# Altium schematic sheet Mosfet_and_Driver.SchDoc(Mosfet_and_Driver)
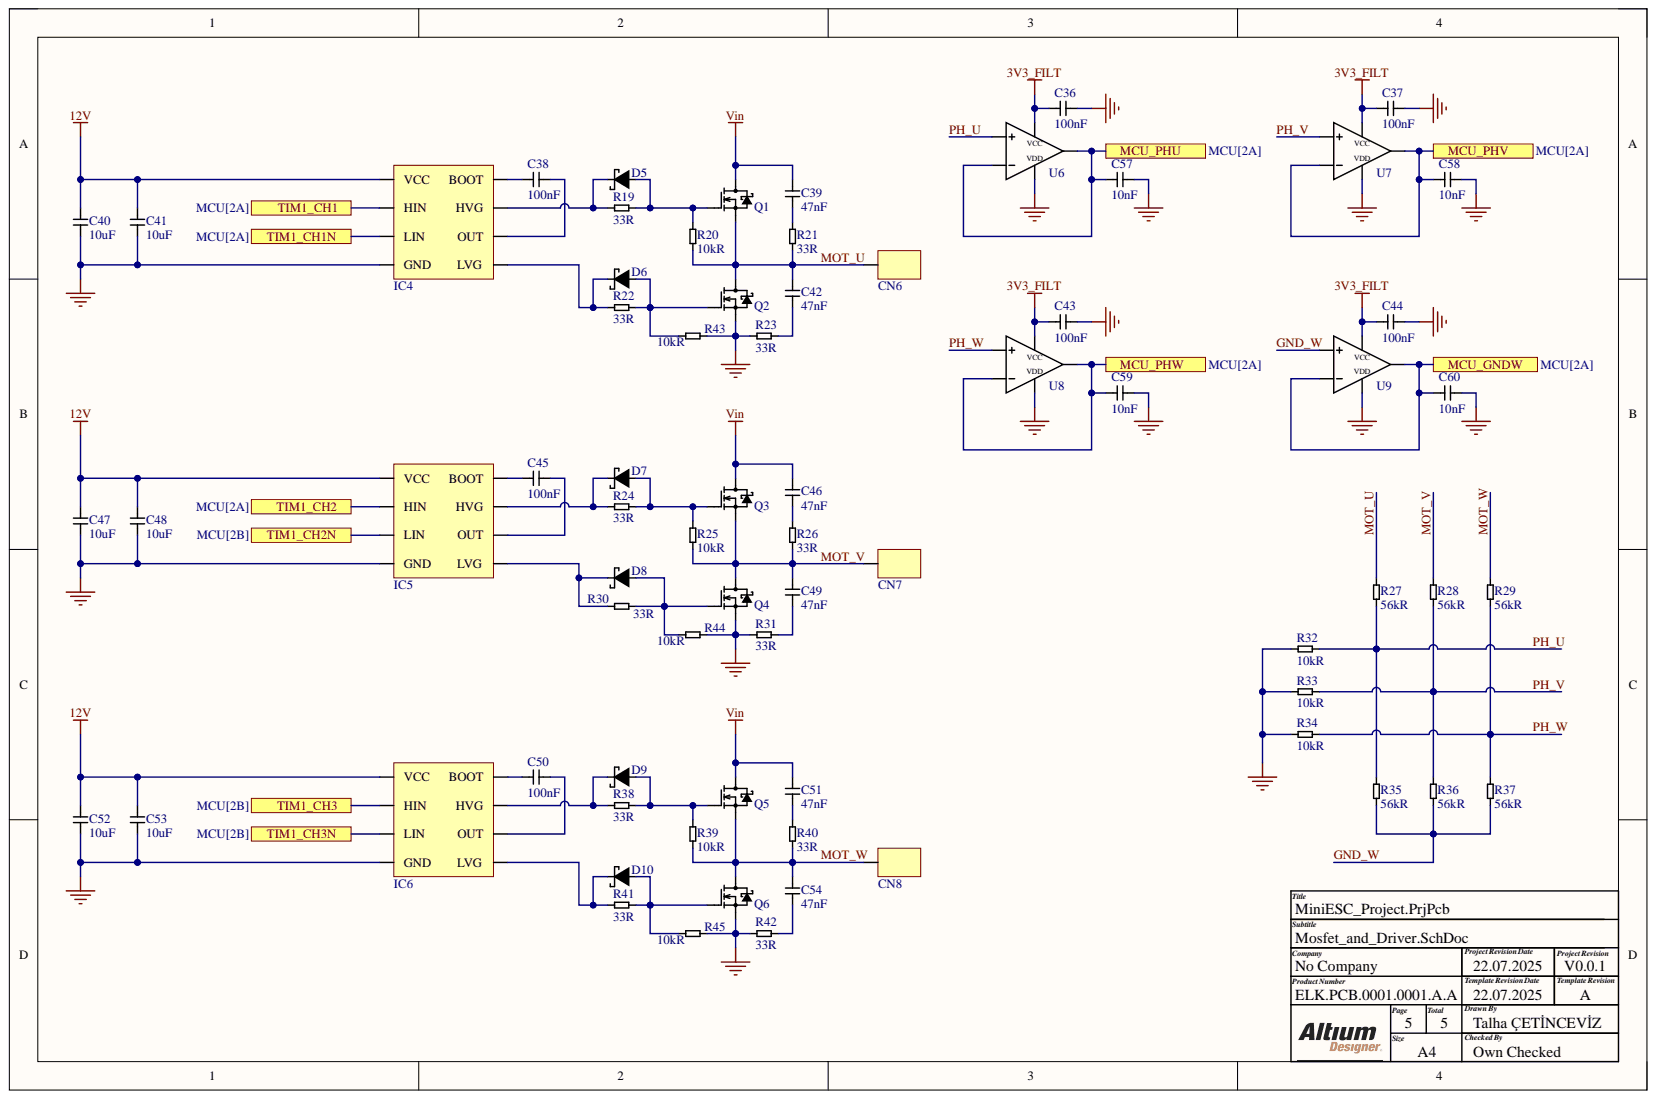
[diagram p0: Mosfet_and_Driver.SchDoc]

## Parts
C36  value 100nF  [CAP 0603]  (hidden comment: CC0603KRX7R9BB104)
C37  value 100nF  [CAP 0603]  (hidden comment: CC0603KRX7R9BB104)
C38  value 100nF  [CAP 0805]  (hidden comment: CL21B104KBCNNNC)
C39  value 47nF  [CAP 1206]  (hidden comment: CL31B473KBCNNNC)
C40  value 10uF  [CAP 0805]  (hidden comment: CL21A106KAYNNNE)
C41  value 10uF  [CAP 0805]  (hidden comment: CL21A106KAYNNNE)
C42  value 47nF  [CAP 1206]  (hidden comment: CL31B473KBCNNNC)
C43  value 100nF  [CAP 0603]  (hidden comment: CC0603KRX7R9BB104)
C44  value 100nF  [CAP 0603]  (hidden comment: CC0603KRX7R9BB104)
C45  value 100nF  [CAP 0805]  (hidden comment: CL21B104KBCNNNC)
C46  value 47nF  [CAP 1206]  (hidden comment: CL31B473KBCNNNC)
C47  value 10uF  [CAP 0805]  (hidden comment: CL21A106KAYNNNE)
C48  value 10uF  [CAP 0805]  (hidden comment: CL21A106KAYNNNE)
C49  value 47nF  [CAP 1206]  (hidden comment: CL31B473KBCNNNC)
C50  value 100nF  [CAP 0805]  (hidden comment: CL21B104KBCNNNC)
C51  value 47nF  [CAP 1206]  (hidden comment: CL31B473KBCNNNC)
C52  value 10uF  [CAP 0805]  (hidden comment: CL21A106KAYNNNE)
C53  value 10uF  [CAP 0805]  (hidden comment: CL21A106KAYNNNE)
C54  value 47nF  [CAP 1206]  (hidden comment: CL31B473KBCNNNC)
C57  value 10nF  [CAP 0603]  (hidden comment: CL10B103KB8NNNC)
C58  value 10nF  [CAP 0603]  (hidden comment: CL10B103KB8NNNC)
C59  value 10nF  [CAP 0603]  (hidden comment: CL10B103KB8NNNC)
C60  value 10nF  [CAP 0603]  (hidden comment: CL10B103KB8NNNC)
CN6  SMD PAD 1  [SMD PAD 1]  (value not drawn)  (no BOM)
CN7  SMD PAD 1  [SMD PAD 1]  (value not drawn)  (no BOM)
CN8  SMD PAD 1  [SMD PAD 1]  (value not drawn)  (no BOM)
D5  SS2060FL_R1_00001  [SOD123-FL]  (value not drawn)
D6  SS2060FL_R1_00001  [SOD123-FL]  (value not drawn)
D7  SS2060FL_R1_00001  [SOD123-FL]  (value not drawn)
D8  SS2060FL_R1_00001  [SOD123-FL]  (value not drawn)
D9  SS2060FL_R1_00001  [SOD123-FL]  (value not drawn)
D10  SS2060FL_R1_00001  [SOD123-FL]  (value not drawn)
IC4  L6388ED013TR  [SOIC-8]  (value not drawn)
IC5  L6388ED013TR  [SOIC-8]  (value not drawn)
IC6  L6388ED013TR  [SOIC-8]  (value not drawn)
Q1  P80FH5ENK-7071  [D2PAK-3]  (value not drawn)
Q2  P80FH5ENK-7071  [D2PAK-3]  (value not drawn)
Q3  P80FH5ENK-7071  [D2PAK-3]  (value not drawn)
Q4  P80FH5ENK-7071  [D2PAK-3]  (value not drawn)
Q5  P80FH5ENK-7071  [D2PAK-3]  (value not drawn)
Q6  P80FH5ENK-7071  [D2PAK-3]  (value not drawn)
R19  value 33R  [RES 0805]  (hidden comment: 0805S8F330JT5E)
R20  value 10kR  [RES 0603]  (hidden comment: RC0603FR-0710KL)
R21  value 33R  [RES 1206]  (hidden comment: CQ06S4F330JT5E)
R22  value 33R  [RES 0805]  (hidden comment: 0805S8F330JT5E)
R23  value 33R  [RES 1206]  (hidden comment: CQ06S4F330JT5E)
R24  value 33R  [RES 0805]  (hidden comment: 0805S8F330JT5E)
R25  value 10kR  [RES 0603]  (hidden comment: RC0603FR-0710KL)
R26  value 33R  [RES 1206]  (hidden comment: CQ06S4F330JT5E)
R27  value 56kR  [RES 0603]  (hidden comment: 0603SAF5602T5E)
R28  value 56kR  [RES 0603]  (hidden comment: 0603SAF5602T5E)
R29  value 56kR  [RES 0603]  (hidden comment: 0603SAF5602T5E)
R30  value 33R  [RES 0805]  (hidden comment: 0805S8F330JT5E)
R31  value 33R  [RES 1206]  (hidden comment: CQ06S4F330JT5E)
R32  value 10kR  [RES 0603]  (hidden comment: RC0603FR-0710KL)
R33  value 10kR  [RES 0603]  (hidden comment: RC0603FR-0710KL)
R34  value 10kR  [RES 0603]  (hidden comment: RC0603FR-0710KL)
R35  value 56kR  [RES 0603]  (hidden comment: 0603SAF5602T5E)
R36  value 56kR  [RES 0603]  (hidden comment: 0603SAF5602T5E)
R37  value 56kR  [RES 0603]  (hidden comment: 0603SAF5602T5E)
R38  value 33R  [RES 0805]  (hidden comment: 0805S8F330JT5E)
R39  value 10kR  [RES 0603]  (hidden comment: RC0603FR-0710KL)
R40  value 33R  [RES 1206]  (hidden comment: CQ06S4F330JT5E)
R41  value 33R  [RES 0805]  (hidden comment: 0805S8F330JT5E)
R42  value 33R  [RES 1206]  (hidden comment: CQ06S4F330JT5E)
R43  value 10kR  [RES 0603]  (hidden comment: RC0603FR-0710KL)
R44  value 10kR  [RES 0603]  (hidden comment: RC0603FR-0710KL)
R45  value 10kR  [RES 0603]  (hidden comment: RC0603FR-0710KL)
U6  LMV321ILT  [SOT23-5]  (value not drawn)
U7  LMV321ILT  [SOT23-5]  (value not drawn)
U8  LMV321ILT  [SOT23-5]  (value not drawn)
U9  LMV321ILT  [SOT23-5]  (value not drawn)

## Ports
MCU_GNDW, MCU_PHU, MCU_PHV, MCU_PHW, TIM1_CH1, TIM1_CH1N, TIM1_CH2, TIM1_CH2N, TIM1_CH3, TIM1_CH3N

## Nets
3V3_FILT: C36.1 C37.1 C43.1 C44.1 U6.5 U7.5 U8.5 U9.5
12V: C40.2 C41.2 C47.2 C48.2 C52.2 C53.2 IC4.3(VCC) IC5.3(VCC) IC6.3(VCC)
GND: C36.2 C37.2 C40.1 C41.1 C43.2 C44.2 C47.1 C48.1 C52.1 C53.1 C57.2 C58.2 C59.2 C60.2 IC4.4(GND) IC5.4(GND) IC6.4(GND) Q2.3 Q4.3 Q6.3 R23.2 R31.2 R32.2 R33.2 R34.2 R42.2 R43.2 R44.2 R45.2 U6.2 U7.2 U8.2 U9.2
Vin: C39.2 C46.2 C51.2 Q1.2 Q3.2 Q5.2
GND_W: R35.1 R36.1 R37.1 U9.1
MCU_GNDW: C60.1 U9.3 U9.4 ; port MCU_GNDW
MCU_PHU: C57.1 U6.3 U6.4 ; port MCU_PHU
MCU_PHV: C58.1 U7.3 U7.4 ; port MCU_PHV
MCU_PHW: C59.1 U8.3 U8.4 ; port MCU_PHW
MOT_U: C42.2 CN6.1 Q1.3 Q2.2 R20.1 R21.1 R27.2
MOT_V: C49.2 CN7.1 Q3.3 Q4.2 R25.1 R26.1 R28.2
MOT_W: C54.2 CN8.1 Q5.3 Q6.2 R29.2 R39.1 R40.1
PH_U: R27.1 R32.1 R35.2 U6.1
PH_V: R28.1 R33.1 R36.2 U7.1
PH_W: R29.1 R34.1 R37.2 U8.1
TIM1_CH1: IC4.2(HIN) ; port TIM1_CH1
TIM1_CH1N: IC4.1(LIN) ; port TIM1_CH1N
TIM1_CH2: IC5.2(HIN) ; port TIM1_CH2
TIM1_CH2N: IC5.1(LIN) ; port TIM1_CH2N
TIM1_CH3: IC6.2(HIN) ; port TIM1_CH3
TIM1_CH3N: IC6.1(LIN) ; port TIM1_CH3N
NetC38_1: C38.1 IC4.8(BOOT)
NetC38_2: C38.2 IC4.6(OUT)
NetC39_1: C39.1 R21.2
NetC42_1: C42.1 R23.1
NetC45_1: C45.1 IC5.8(BOOT)
NetC45_2: C45.2 IC5.6(OUT)
NetC46_1: C46.1 R26.2
NetC49_1: C49.1 R31.1
NetC50_1: C50.1 IC6.8(BOOT)
NetC50_2: C50.2 IC6.6(OUT)
NetC51_1: C51.1 R40.2
NetC54_1: C54.1 R42.1
NetD5_A: D5.A Q1.1 R19.2 R20.2
NetD5_C: D5.C IC4.7(HVG) R19.1
NetD6_A: D6.A Q2.1 R22.2 R43.1
NetD6_C: D6.C IC4.5(LVG) R22.1
NetD7_A: D7.A Q3.1 R24.2 R25.2
NetD7_C: D7.C IC5.7(HVG) R24.1
NetD8_A: D8.A Q4.1 R30.2 R44.1
NetD8_C: D8.C IC5.5(LVG) R30.1
NetD9_A: D9.A Q5.1 R38.2 R39.2
NetD9_C: D9.C IC6.7(HVG) R38.1
NetD10_A: D10.A Q6.1 R41.2 R45.1
NetD10_C: D10.C IC6.5(LVG) R41.1

## Library data of the parts
C36 C37 C43 C44 = CC0603KRX7R9BB104: Multilayer Ceramic Capacitor, CC Series, 0.1 - F, - 10%, X7R, 50 V, 0603 [1608 Metric]; Manufacturer: Yageo; Dielectric Material: 4241ConductorMaterial; Voltage: 50V; Case Code (Metric): 1608; Case Code (Imperial): 0603; Case/Package: 0603; Category: Ceramic Capacitors; Component Kind: Standard; ComponentLink1Description: https://datasheet.ciiva.com/11786/0900766b80d84f1d-11786061.pdf?src-supplier=Rs; ComponentLink1URL: https://datasheet.ciiva.com/11786/0900766b80d84f1d-11786061.pdf?src-supplier=Rs; ComponentLink2Description: https://datasheet.ciiva.com/8033/70765-8033821.pdf?src-supplier=Element14; ComponentLink2URL: https://datasheet.ciiva.com/8033/70765-8033821.pdf?src-supplier=Element14; ComponentLink3Description: https://datasheet.ciiva.com/7978/1489800-7978166.pdf?src-supplier=Element14; ComponentLink3URL: https://datasheet.ciiva.com/7978/1489800-7978166.pdf?src-supplier=Element14; ComponentLink4Description: https://datasheet.ciiva.com/144/mlccorderingcode-144177.pdf?src-supplier=Digikey; ComponentLink4URL: https://datasheet.ciiva.com/144/mlccorderingcode-144177.pdf?src-supplier=Digikey; ComponentLink5Description: https://datasheet.ciiva.com/144/mlccorderingcode-144176.pdf?src-supplier=Digikey; ComponentLink5URL: https://datasheet.ciiva.com/144/mlccorderingcode-144176.pdf?src-supplier=Digikey; ComponentLink6Description: https://datasheet.ciiva.com/144/mlccorderingcode-144178.pdf?src-supplier=Digikey; ComponentLink6URL: https://datasheet.ciiva.com/144/mlccorderingcode-144178.pdf?src-supplier=Digikey; ComponentLink7Description: https://datasheet.ciiva.com/8033/70765-8033819.pdf?src-supplier=Element14; ComponentLink7URL: https://datasheet.ciiva.com/8033/70765-8033819.pdf?src-supplier=Element14; ComponentLink8Description: https://datasheet.ciiva.com/31394/1489800-31394927.pdf?src-supplier=Farnell; ComponentLink8URL: https://datasheet.ciiva.com/31394/1489800-31394927.pdf?src-supplier=Farnell; ComponentLink9Description: https://datasheet.ciiva.com/22749/1489800-22749214.pdf?src-supplier=Element14; ComponentLink9URL: https://datasheet.ciiva.com/22749/1489800-22749214.pdf?src-supplier=Element14; Depth: 0.8mm; Dielectric: X7R; Halogen Free: 5694HalogenFree; Height: 0.8mm; Lead Free: 5697LeadFree; Length: 0.063inch; Library Name: ESC_Int.IntLib; Manufacturer Part Number: CC0603KRX7R9BB104; Material: 4241ConductorMaterial; Max Operating Temperature: 125°C; Min Operating Temperature: -55°C; Mounting Technology: Surface Mount; Package Quantity: 1; Packaging: Tape and Reel; Pins: 2; Pricing: 4000=0,0018, 20000=0,0017, 80000=0,0017, 200000=0,0016, 500000=0,0015 (USD); Radiation Hardening: No; Reach SVHC Compliant: No; RoHS: Yes; RoHS Compliant: Yes; Series: CC; Stock: 5600000; Supplier: Ozdisan; Supplier Part Number: CC0603KRX7R9BB104; Termination: SMD/SMT; Thickness: 0.035inch; Tolerance: 10%; Voltage Rating: 50V; Width: 0.031inch
C38 C45 C50 = CL21B104KBCNNNC: 50V 100nF X7R ±10% 0805 Multilayer Ceramic Capacitors MLCC - SMD/SMT ROHS; Manufacturer: Samsung; Case Code (Metric): 2012; Case Code (Imperial): 0805; Case/Package: 0805; Category: Ceramic Capacitors; Component Kind: Standard; ComponentLink1Description: https://datasheet.ciiva.com/25710/cl21b104kbcnnnc-25710182.pdf?src-supplier=Digikey; ComponentLink1URL: https://datasheet.ciiva.com/25710/cl21b104kbcnnnc-25710182.pdf?src-supplier=Digikey; ComponentLink2Description: https://datasheet.ciiva.com/29199/454152-da-01-en-vs-kondensator-0805-29199814.pdf?src-supplier=Conrad; ComponentLink2URL: https://datasheet.ciiva.com/29199/454152-da-01-en-vs-kondensator-0805-29199814.pdf?src-supplier=Conrad; Construction: Flat; Depth: 1.25mm; Dielectric: X7R; Height: 0.85mm; Lead Free: 5697LeadFree; Length: 2mm; Library Name: ESC_Int.IntLib; Manufacturer Part Number: CL21B104KBCNNNC; Material: Ceramic; Max Operating Temperature: 125°C; Min Operating Temperature: -55°C; Mounting Technology: Surface Mount; Packaging: Tape and Reel; Pricing: 4000=0,00539, 8000=0,00525, 16000=0,00508, 24000=0,0049, 32000=0,00473 (USD); Radiation Hardening: No; RoHS: Yes; RoHS Compliant: Yes; Series: CL; Stock: 648000; Supplier: Ozdisan; Supplier Part Number: CL21B104KBCNNNC; Thickness: 0.037inch; Tolerance: 10%; Voltage: 50V; Voltage Rating: 50V; Voltage Rating (DC): 50V; Width: 0.049inch
C39 C42 C46 C49 C51 C54 = CL31B473KBCNNNC: MLCC (3216) 1206 47nF 50VDC ±10% X7R; Manufacturer: Samsung; Material: Ceramic; Tolerance: %10; Voltage Rating (DC): 50V; Case/Package: 1206; Category: Ceramic Capacitors; Component Kind: Standard; ComponentLink1Description: https://datasheet.ciiva.com/15196/getdatasheetpartid-358694-15196056.pdf?src-supplier=Verical; ComponentLink1URL: https://datasheet.ciiva.com/15196/getdatasheetpartid-358694-15196056.pdf?src-supplier=Verical; ComponentLink2Description: https://datasheet.ciiva.com/29201/452141-da-01-en-vs-kondensator-1206-29201464.pdf?src-supplier=Conrad; ComponentLink2URL: https://datasheet.ciiva.com/29201/452141-da-01-en-vs-kondensator-1206-29201464.pdf?src-supplier=Conrad; ComponentLink3Description: https://datasheet.ciiva.com/16428/cl05b104kq5nnnc-16428956.pdf?src-supplier=Future+Electronics; ComponentLink3URL: https://datasheet.ciiva.com/16428/cl05b104kq5nnnc-16428956.pdf?src-supplier=Future+Electronics; Dielectric: X7R; Library Name: ESC_Int.IntLib; Manufacturer Part Number: CL31B473KBCNNNC; Max Operating Temperature: 125°C; Min Operating Temperature: -55°C; Pricing: 16000=0,0114 (USD); RoHS: Yes; Stock: 308000; Supplier: Ozdisan; Supplier Part Number: CL31B473KBCNNNC
C40 C41 C47 C48 C52 C53 = CL21A106KAYNNNE: 25V 10uF X5R ±10% 0805 Multilayer Ceramic Capacitors MLCC - SMD/SMT ROHS; Manufacturer: Samsung; Case Code (Metric): 2012; Case Code (Imperial): 0805; Case/Package: 0805; Category: Ceramic Capacitors; Component Kind: Standard; ComponentLink1Description: https://datasheet.ciiva.com/25796/cl21a106kaynnne-25796720.pdf?src-supplier=Digikey; ComponentLink1URL: https://datasheet.ciiva.com/25796/cl21a106kaynnne-25796720.pdf?src-supplier=Digikey; ComponentLink2Description: https://datasheet.ciiva.com/26838/getdatasheetpartid-357558-26838583.pdf?src-supplier=Verical; ComponentLink2URL: https://datasheet.ciiva.com/26838/getdatasheetpartid-357558-26838583.pdf?src-supplier=Verical; Construction: Flat; Depth: 1.25mm; Dielectric: X5R; Height: 1.25mm; Length: 2mm; Library Name: ESC_Int.IntLib; Manufacturer Part Number: CL21A106KAYNNNE; Material: Ceramic; Max Operating Temperature: 85°C; Min Operating Temperature: -55°C; Mounting Technology: Surface Mount; Package Quantity: 1; Packaging: Tape and Reel; Pricing: 2000=0,00823 (USD); Radiation Hardening: No; RoHS: Yes; RoHS Compliant: Yes; Series: CL; Stock: 3442000; Supplier: Ozdisan; Supplier Part Number: CL21A106KAYNNNE; Thickness: 0.057inch; Tolerance: 10%; Voltage Rating: 25V; Voltage Rating (DC): 25V; Width: 0.049inch
C57-C60 = CL10B103KB8NNNC: 50V 10nF X7R ±10% 0603 Multilayer Ceramic Capacitors MLCC - SMD/SMT ROHS; Manufacturer: Samsung; Case Code (Metric): 1608; Case Code (Imperial): 0603; Case/Package: 0603; Category: Ceramic Capacitors; Component Kind: Standard; ComponentLink1Description: https://datasheet.ciiva.com/15195/getdatasheetpartid-357415-15195721.pdf?src-supplier=Verical; ComponentLink1URL: https://datasheet.ciiva.com/15195/getdatasheetpartid-357415-15195721.pdf?src-supplier=Verical; ComponentLink2Description: https://datasheet.ciiva.com/29198/457455-da-01-en-vs-kondensator-0603-29198644.pdf?src-supplier=Conrad; ComponentLink2URL: https://datasheet.ciiva.com/29198/457455-da-01-en-vs-kondensator-0603-29198644.pdf?src-supplier=Conrad; Construction: Flat; Depth: 0.8mm; Dielectric: X7R; Height: 0.8mm; Lead Free: 5697LeadFree; Length: 1.6mm; Library Name: ESC_Int.IntLib; Manufacturer Part Number: CL10B103KB8NNNC; Material: Ceramic; Max Operating Temperature: 125°C; Min Operating Temperature: -55°C; Mounting Technology: Surface Mount; Package Quantity: 1; Packaging: Tape and Reel; Pricing: 4000=0,00299, 8000=0,00291, 16000=0,00281, 24000=0,00272, 32000=0,00262 (USD); Radiation Hardening: No; RoHS: Yes; RoHS Compliant: Yes; Series: CL; Stock: 2448000; Supplier: Ozdisan; Supplier Part Number: CL10B103KB8NNNC; Thickness: 0.035inch; Tolerance: 10%; Voltage: 50V; Voltage Rating: 50V; Voltage Rating (DC): 50V; Width: 0.031inch
D5-D10 = SS2060FL_R1_00001: Schottky-diode 2A 60V SOD123FL; Manufacturer: Pan Jit; Max Reverse Current: 500uA; Peak Non-Repetitive Surge Current: 50A; Category: Rectifier Diodes; Component Kind: Standard; ComponentLink1Description: http://www.panjit.com/upload/product/5/SS2020FL_SERIES.pdf?src-supplier=Avnet; ComponentLink1URL: http://www.panjit.com/upload/product/5/SS2020FL_SERIES.pdf?src-supplier=Avnet; Library Name: ESC_Int.IntLib; Manufacturer Part Number: SS2060FL_R1_00001; Max Operating Temperature: 125°C; Max Repetitive Reverse Voltage (Vrrm): 60V; Min Operating Temperature: -50°C; Mounting Technology: Surface Mount; Pins: 2; Pricing: 1=0,36, 10=0,232, 50=0,232, 100=0,161, 1000=0,107, 10000=0,067 (USD); RoHS: Yes; RoHS Compliant: Yes; Stock: 6399; Supplier: Ozdisan; Supplier Part Number: SS2060FL_R1_00001
IC4-IC6 = L6388ED013TR: L6388E Series 17 V 650 mA High Voltage High and Low-Side Driver - SOIC-8; Manufacturer: STMicroelectronics; Number of Channels: 2NUMBER; Output Voltage: 580V; Undervoltage Threshold: 8.3V; Value: 125R; Case/Package: SOIC-8; Category: Gate Drivers; Component Kind: Standard; ComponentLink1Description: https://datasheet.ciiva.com/1950/cd00049626-1950093.pdf?src-supplier=Digikey; ComponentLink1URL: https://datasheet.ciiva.com/1950/cd00049626-1950093.pdf?src-supplier=Digikey; ComponentLink2Description: https://datasheet.ciiva.com/1950/cd00049626-1950092.pdf?src-supplier=Digikey; ComponentLink2URL: https://datasheet.ciiva.com/1950/cd00049626-1950092.pdf?src-supplier=Digikey; ComponentLink3Description: https://datasheet.ciiva.com/1950/cd00049626-1950094.pdf?src-supplier=Digikey; ComponentLink3URL: https://datasheet.ciiva.com/1950/cd00049626-1950094.pdf?src-supplier=Digikey; ComponentLink4Description: https://datasheet.ciiva.com/41457/001185561-da-01-en-ic-driver-hi-lo-l6388ed013tr-soic-8-stm-41457639.pdf?src-supplier=Conrad; ComponentLink4URL: https://datasheet.ciiva.com/41457/001185561-da-01-en-ic-driver-hi-lo-l6388ed013tr-soic-8-stm-41457639.pdf?src-supplier=Conrad; Lead Free: 5697LeadFree; Library Name: ESC_Int.IntLib; Manufacturer Part Number: L6388ED013TR; Max Operating Temperature: 125°C; Min Operating Temperature: -40°C; Pricing: 2500=0,87, 5000=0,86, 7500=0,85 (USD); RoHS: Yes; Stock: 37500; Supplier: Ozdisan; Supplier Part Number: L6388ED013TR
Q1-Q6 = P80FH5ENK-7071: MOSFET POWER 80A 50V N-CH TO263AB; Manufacturer: SHINDENGEN; Power Dissipation: 128W; Rds On: 3mR; Trr: 47nS; Case/Package: TO263-3 (D2PAK-3); Component Kind: Standard; Current (25°C): 80A; Library Name: ESC_Int.IntLib; Manufacturer Part Number: P80FH5ENK-7071; Max Operating Temperature: 150°C; Min Operating Temperature: -55°C; RoHS: RoHS; Supplier: Ozdisan; Supplier Part Number: P80FH5ENK-7071
R19 R22 R24 R30 R38 R41 = 0805S8F330JT5E: Res General Purpose Thick Film 0805 33 Ohm 1% 1/8W ±200ppm/°C Molded T/R; Manufacturer: Multicomp; Manufacturer Part Number: MC0805S8F330JT5E; Power Rating: 125mW; Temperature Coefficient: 100ppm/°C; Voltage Rating: 150V; Case Code (Metric): 2012; Case Code (Imperial): 0805; Case/Package: 0805; Category: Resistors; Component Kind: Standard; ComponentLink1Description: http://www.newark.com/pdfs/datasheets/spc/TA-677.pdf?src-supplier=Farnell; ComponentLink1URL: http://www.newark.com/pdfs/datasheets/spc/TA-677.pdf?src-supplier=Farnell; ComponentLink2Description: https://datasheet.octopart.com/MC0805S8F330JT5E-Multicomp-datasheet-14877041.pdf?src-supplier=Element14; ComponentLink2URL: https://datasheet.octopart.com/MC0805S8F330JT5E-Multicomp-datasheet-14877041.pdf?src-supplier=Element14; Library Name: ESC_Int.IntLib; Packaging: Cut Tape; Pins: 2; Pricing: 5000=0,029 (EUR); Reach SVHC Compliant: Unknown; Resistance Tolerance: 1%; Resistor Type: Thick Film; RoHS: Yes; Series: MC; Stock: 1106; Supplier: Ozdisan; Supplier Part Number: 0805S8F330JT5E; Voltage Rating (DC): 150V
R20 R25 R32-R34 R39 R43-R45 = RC0603FR-0710KL: SMD Chip Resistor, 10 Kohm, ½ 1%, 100 Mw, 0603 [1608 Metric], Thick Film, General Purpose; Manufacturer: Yageo; Max Power Dissipation: 100mW; Temperature Coefficient: 100ppm/°C; Voltage Rating: 75V; Voltage Rating (DC): 50V; Case Code (Metric): 1608; Case Code (Imperial): 0603; Case/Package: 0603; Category: Chip SMD Resistors; Component Kind: Standard; ComponentLink1Description: https://datasheet.ciiva.com/7660/38590-7660789.pdf?src-supplier=Element14; ComponentLink1URL: https://datasheet.ciiva.com/7660/38590-7660789.pdf?src-supplier=Element14; ComponentLink2Description: https://datasheet.ciiva.com/7983/443971-7983596.pdf?src-supplier=Element14; ComponentLink2URL: https://datasheet.ciiva.com/7983/443971-7983596.pdf?src-supplier=Element14; ComponentLink3Description: https://datasheet.ciiva.com/22747/443971-22747519.pdf?src-supplier=Element14; ComponentLink3URL: https://datasheet.ciiva.com/22747/443971-22747519.pdf?src-supplier=Element14; ComponentLink4Description: https://datasheet.ciiva.com/46440/1868787-46440891.pdf?src-supplier=Element14; ComponentLink4URL: https://datasheet.ciiva.com/46440/1868787-46440891.pdf?src-supplier=Element14; ComponentLink5Description: https://datasheet.ciiva.com/7746/1738695-7746239.pdf?src-supplier=Element14; ComponentLink5URL: https://datasheet.ciiva.com/7746/1738695-7746239.pdf?src-supplier=Element14; ComponentLink6Description: https://datasheet.ciiva.com/15189/getdatasheetpartid-409289-15189591.pdf?src-supplier=Verical; ComponentLink6URL: https://datasheet.ciiva.com/15189/getdatasheetpartid-409289-15189591.pdf?src-supplier=Verical; Construction: Rectangular; Depth: 0.8mm; Features: Moisture Resistant; Height: 0.45mm; Lead Free: 5697LeadFree; Lead Pitch: 0.3mm; Length: 1.6mm; Library Name: ESC_Int.IntLib; Manufacturer Part Number: RC0603FR-0710KL; Max Operating Temperature: 155°C; Military Standard: Not; Min Operating Temperature: -55°C; Number of Terminations: 2; Packaging: Tape and Reel; Pins: 2; Power Rating: 100mW; Pricing: 5000=0,001, 25000=0,001, 150000=0,001, 500000=0,0009, 1500000=0,0009 (USD); Reach SVHC Compliant: No; Resistance Tolerance: 1%; Resistor Type: Thick Film; RoHS: Yes; RoHS Compliant: Yes; Series: RC; Stock: 42525000; Supplier: Ozdisan; Supplier Part Number: RC0603FR-0710KL; Termination: SMD/SMT; Tolerance: 1%; Weight: 7.1E-05oz; Width: 0.8mm
R21 R23 R26 R31 R40 R42 = CQ06S4F330JT5E: RES.(3216) 1206 33 Ohms 1% 1/4W-S AUTOMOTIV; Manufacturer: Royal Electronic Factory; Power Rating: 250mW; Resistance Tolerance: %1; Temperature Coefficient: 100 PPM; Voltage Rating (DC): 200V; Case/Package: 1206; Category: Resistors; Component Kind: Standard; Library Name: ESC_Int.IntLib; Manufacturer Part Number: CQ06S4F330JT5E; Max Operating Temperature: 155°C; Min Operating Temperature: -55°C; Pricing: 10000=0,004 (USD); RoHS: No; Supplier: Ozdisan; Supplier Part Number: CQ06S4F330JT5E
R27-R29 R35-R37 = 0603SAF5602T5E: Res Thick Film 0603 56K Ohm 1% 0.1W(1/10W) ±100ppm/C Pad SMD T/R; Manufacturer: Royal Electronic Factory; Resistance Tolerance: %1; Temperature Coefficient: 100ppm/°C; Voltage Rating (DC): 100mW; Case/Package: 0603; Component Kind: Standard; ComponentLink1Description: https://datasheet.octopart.com/0603SAF5602T5E-Royal-Ohm-datasheet-165785611.pdf?src-supplier=TME; ComponentLink1URL: https://datasheet.octopart.com/0603SAF5602T5E-Royal-Ohm-datasheet-165785611.pdf?src-supplier=TME; Library Name: ESC_Int.IntLib; Manufacturer Part Number: 0603SAF5602T5E; Max Operating Temperature: 155°C; Min Operating Temperature: -55°C; Pricing: 10000=0,001 (USD); RoHS: No; Stock: 5000; Supplier: Ozdisan; Supplier Part Number: 0603SAF5602T5E
U6-U9 = LMV321ILT: LMV321 Series 6 V 1 MHz Low Power Rail to Rail Operational Amplifier - SOT-23-5; Manufacturer: STMicroelectronics; Case/Package: SOT23-5; Category: Amplifiers - Op Amps, Buffer, Instrumentation; Component Kind: Standard; ComponentLink1Description: https://datasheet.ciiva.com/41459/001185379-da-01-en-ic-op-amp-sgl-r-r-lmv321ilt-sot-23-5-stm-41459833.pdf?src-supplier=Conrad; ComponentLink1URL: https://datasheet.ciiva.com/41459/001185379-da-01-en-ic-op-amp-sgl-r-r-lmv321ilt-sot-23-5-stm-41459833.pdf?src-supplier=Conrad; ComponentLink2Description: https://datasheet.ciiva.com/16449/cd00079372-16449002.pdf?src-supplier=Future+Electronics; ComponentLink2URL: https://datasheet.ciiva.com/16449/cd00079372-16449002.pdf?src-supplier=Future+Electronics; ComponentLink3Description: https://datasheet.ciiva.com/1795/cd00079372-1795891.pdf?src-supplier=Digikey; ComponentLink3URL: https://datasheet.ciiva.com/1795/cd00079372-1795891.pdf?src-supplier=Digikey; ComponentLink4Description: https://datasheet.ciiva.com/1795/cd00079372-1795892.pdf?src-supplier=Digikey; ComponentLink4URL: https://datasheet.ciiva.com/1795/cd00079372-1795892.pdf?src-supplier=Digikey; ComponentLink5Description: https://datasheet.ciiva.com/1795/cd00079372-1795893.pdf?src-supplier=Digikey; ComponentLink5URL: https://datasheet.ciiva.com/1795/cd00079372-1795893.pdf?src-supplier=Digikey; ComponentLink6Description: https://datasheet.ciiva.com/11710/cd00079372-11710214.pdf?src-supplier=Avnet; ComponentLink6URL: https://datasheet.ciiva.com/11710/cd00079372-11710214.pdf?src-supplier=Avnet; ComponentLink7Description: https://datasheet.ciiva.com/8008/1733540-8008505.pdf?src-supplier=Element14; ComponentLink7URL: https://datasheet.ciiva.com/8008/1733540-8008505.pdf?src-supplier=Element14; ComponentLink8Description: https://datasheet.ciiva.com/8008/1733540-8008506.pdf?src-supplier=Element14; ComponentLink8URL: https://datasheet.ciiva.com/8008/1733540-8008506.pdf?src-supplier=Element14; Lead Free: 5697LeadFree; Library Name: ESC_Int.IntLib; Manufacturer Part Number: LMV321ILT; Max Operating Temperature: 125°C; Min Operating Temperature: -40°C; Pricing: 3000=0,0711, 9000=0,0694, 15000=0,0686, 45000=0,0669, 75000=0,0654 (USD); RoHS: Yes; Stock: 405000; Supplier: Ozdisan; Supplier Part Number: LMV321ILT
CN6-CN8 = SMD PAD 1: Description: SMD PAD 1; Library Name: ESC_Int.IntLib
